# Altium schematic sheet Sheet1.SchDoc(Sheet1)
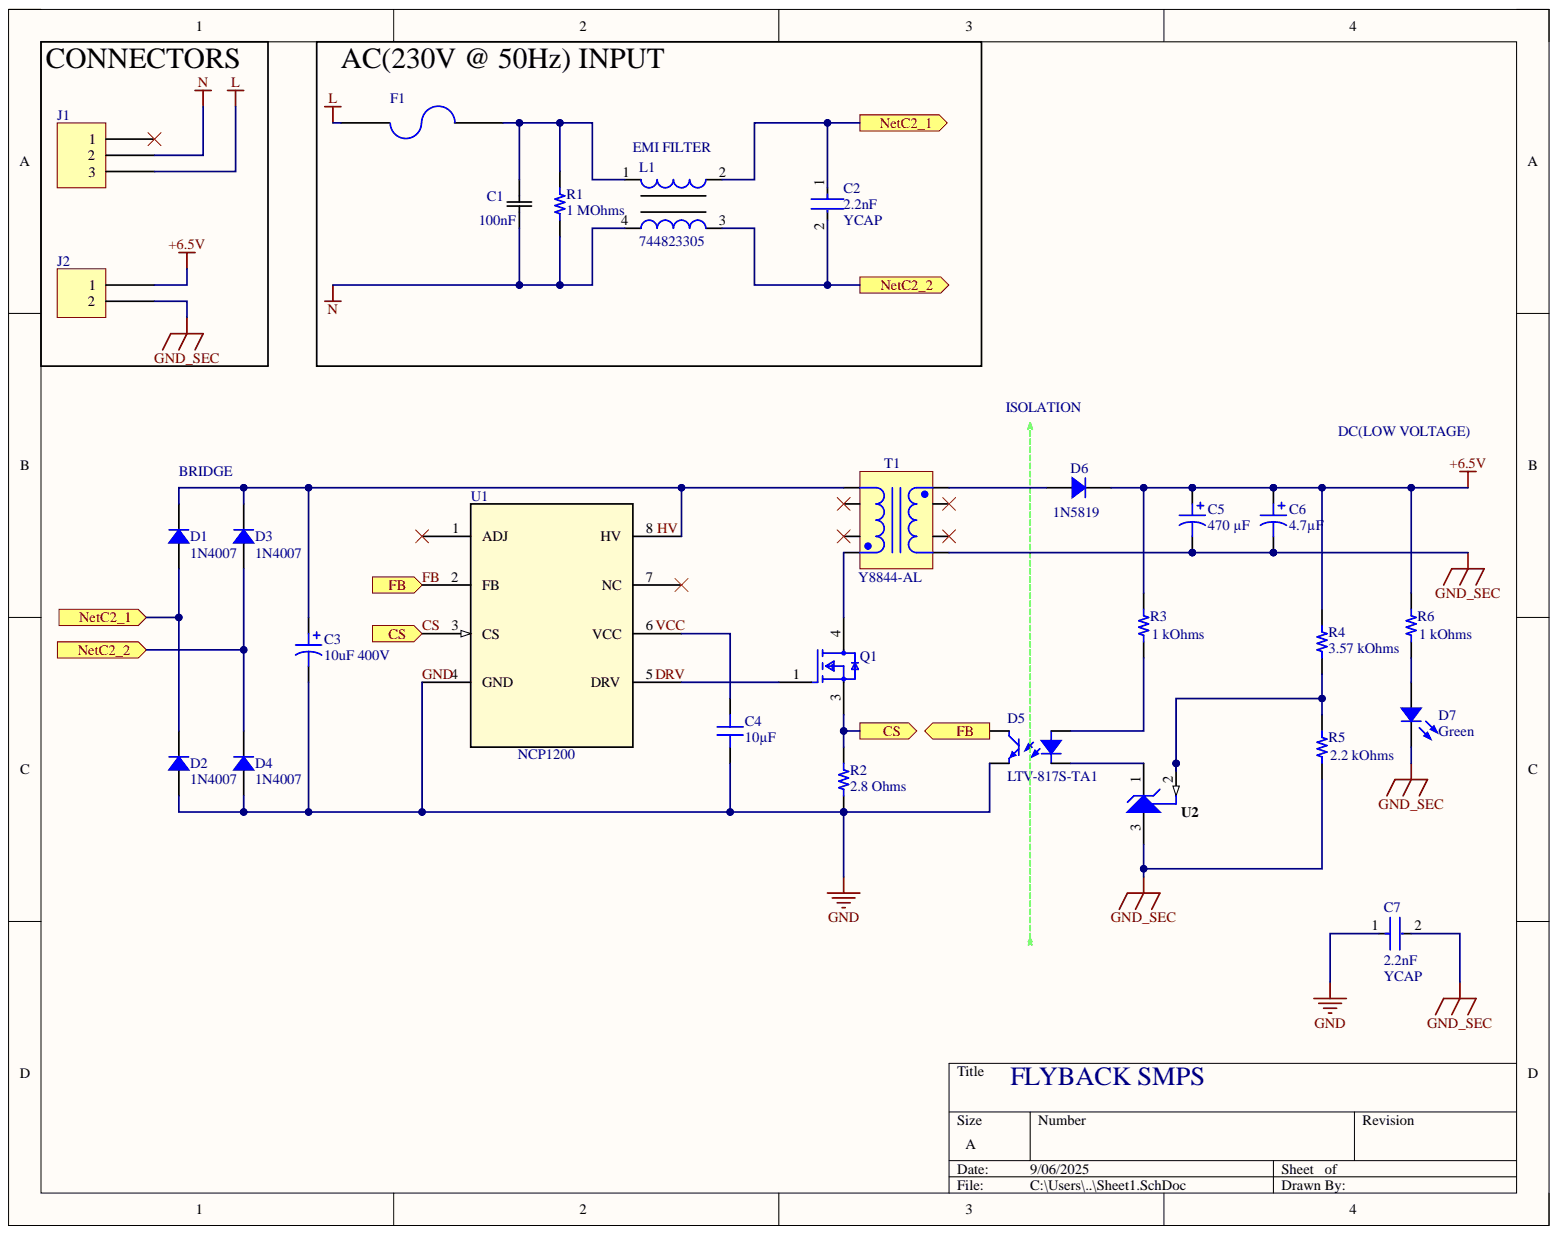
[diagram p0: Sheet1.SchDoc]

## Parts
C1  100nF  [CAP_B32652A--15--8.5x14.5x18_TDK]
C2  YCAP  value 2.2nF  [Vishay_0_MFG]
C3  10uF 400V  [CAP TH ALUM ELEC D10.00mm S5.00mm H17.50mm]
C4  10µF  [CAP 1206_3216 - 1.8MM]
C5  470 µF  [CAP AL TH D8 L3.5 H12.5]
C6  4.7µF  [CAP TH ALUM ELEC D4.00mm S1.50mm H6.00mm]
C7  YCAP  value 2.2nF  [Vishay_0_MFG]
D1  1N4007  [SOD123F]
D2  1N4007  [SOD123F]
D3  1N4007  [SOD123F]
D4  1N4007  [SOD123F]
D5  LTV-817S-TA1  [LITEON LTV-817]
D6  1N5819  [SOD-123]
D7  Green  [LED 0603_1608 GREEN]
F1  0679H2000-05  [FUSE 2410_6125 2]  (value not drawn)
J1  Part Number  [TE 282834-3]  (value not drawn)
J2  282837-2  [TE 282837-2]  (value not drawn)
L1  744823305  [WE-CMB-M]
Q1  STD1NK60T4  [STMicroelectronics_DPAK_TO-252_-0068772_MFG]  (value not drawn)
R1  1 MOhms  [RES 1210_3225]
R2  2.8 Ohms  [RES 1206_3216]
R3  1 kOhms  [RES 0402_1005]
R4  value 3.57 kOhms  [YAGEO RES 1206_3216]  (hidden comment: 220 Ohms)
R5  2.2 kOhms  [RES 0402_1005]
R6  1 kOhms  [RES 0402_1005]
T1  Y8844-AL  [COIL-Y8844-AL-8_V]
U1  NCP1200  [SOIC8_ONS]
U2  TL431AQDBZRQ1  [DBZ0003A_L]  (value not drawn)

## Ports
CS, FB, L filtered, N filtered

## Nets
+6.5V: C5.1 C6.1 D6.2 J2.1 R3.2 R4.2 R6.2
GND_SEC: C5.2 C6.2 C7.2 D7.2 J2.2 R5.1 T1.1 U2.3
L: F1.1 J1.3
N: C1.1 J1.2 L1.4 R1.1
CS: Q1.3 R2.2 U1.3(CS) ; port CS
FB: D5.4 U1.2(FB) ; port FB
GND: C3.2 C4.2 C7.1 D2.1 D4.1 D5.3 R2.1 U1.4(GND)
NetC1_2: C1.2 F1.2 L1.1 R1.2
NetC2_1: C2.1 D1.1 D2.2 L1.2 ; port L filtered
NetC2_2: C2.2 D3.1 D4.2 L1.3 ; port N filtered
NetC3_1: C3.1 D1.2 D3.2 T1.8 U1.8(HV)
NetC4_1: C4.1 U1.6(VCC)
NetD5_1: D5.1 R3.1
NetD5_2: D5.2 U2.1
NetD6_1: D6.1 T1.4
NetD7_1: D7.1 R6.1
NetQ1_1: Q1.1 U1.5(DRV)
NetQ1_4: Q1.4 T1.5
NetR4_1: R4.1 R5.2 U2.2
DRV:
HV:
VCC:

Not connected: J1 1; T1 2 3 6 7; U1 1(ADJ) 7(NC)

## Library data of the parts
C1 = B32652A1103J000: No Description Available; Manufacturer_Name: TDK; Component Kind: Standard; Library Name: transformer.SchLib; Manufacturer_Part_Number: B32652A1103J000; Copyright: Copyright (C) 2025 Ultra Librarian. All rights reserved.
C2 C7 = CMP-001-00084-1: 2200 pF ±20% 500VAC Ceramic Capacitor Y5V (F) Radial, Disc; Manufacturer: Vishay; Part Number: VY1222M37Y5VQ63V0; Symbol Hash: 02cd4be3c70576c341d710602a673aaf100b1e689dd796f6d4934cc5521dd216; Vac X Rating: X1; Vac Y Rating: Y1; Verification Version: 0.0.0.3; Voltage Ac Rating X: 760V; Voltage Ac Rating Y: 500V; Voltage Rating: 1.5kV; Automotive: No; Case/Package: 0; Component Kind: Standard; ComponentLink1Description: Upverter; ComponentLink1URL: https://upverter.com/part/id/97ca20b8586b3509/; ComponentLink2Description: Datasheet; ComponentLink2URL: https://www.vishay.com/docs/28537/vy1series.pdf; Datasheet Path: datasheets/Cap_Vishay_VY1222M37Y5VQ63V0_eec.pdf; Digikey Description: CAP CER 2200PF 500VAC Y5V RADIAL; Digikey Part Number: BC3303-ND; Height: 24.5mm; helpURL: https://upverter.com/part/id/97ca20b8586b3509/; Lead Free: Yes; Material: Ceramic; Mouser Description: VY1 Ceramic Capacitor 2 2NF 20% Y5V; Mouser Part Number: 72-VY1222M37Y5VQ63V0; RoHS: TRUE; Temperature: -40°C to +125°C; Temperature Characteristic: Y5V; Temperature Range High: 125°C; Temperature Range Low: -40°C; Tolerance: 20%; Library Name: Tiberiu's Altium Workspace
C3 = 860241375002: CAP 10 UF 20% 400 V; manufacturer: Würth Elektronik; Capacitance: 10µF; Database Table Name: Capacitors - Aluminum - Electrolytic TH; Lifetime @ Temp.: 10000 Hrs @ 105°C; Polarization: Polar; Ripple Current @ High Frequency: 274mA @ 100kHz; Ripple Current @ Low Frequency: 137mA @ 120Hz; value: 10µF; Applications: General Purpose; Component Kind: Standard; componentlink1description: Datasheet; componentlink1url: https://katalog.we-online.de/pbs/datasheet/860241375002.pdf; componentlink2description: DigiKey Link; componentlink2url: http://digikey.com/product-detail/en/w-rth-elektronik/860241375002/732-9301-1-ND/5729239; Device Package: Radial, Can; Height - Seated (Max): 0.689" (17.50mm); lastupdated: 9/2/2020 1:31:25 AM; Lead Spacing: 0.197" (5.00mm); Library Name: Celestial Altium Library - altium_library.DbLib; Lifecycle Status: Active; Minimum Order: 1; Mounting Type: Through Hole; Operating Temperature: -25°C ~ 105°C; Package / Case: Radial, Can; packaging: TapeAndBox; Part Number: 860241375002; Part Status: Active; partid: 12069; price: 0.48; series: WCAP-AT1H; Size / Dimension: 0.394" Dia (10.00mm); Supplier 1: DigiKey; Supplier Part Number 1: 732-9301-1-ND; Tolerance: ±20%; Voltage - Rated: 400V
C4 = 12065D106KAT2A: CAP CER 10UF 50V X5R 1206; manufacturer: AVX Corporation; Database Table Name: Capacitors - Ceramic - 1206; Tolerance: ±10%; Applications: General Purpose; Capacitance: 10µF; Component Kind: Standard; componentlink1description: Datasheet; componentlink1url: http://datasheets.avx.com/cx5r.pdf; componentlink2description: DigiKey Link; componentlink2url: http://digikey.com/product-detail/en/avx-corporation/12065D106KAT2A/478-9979-1-ND/6149060; Device Package: 1206 (3216 Metric); lastupdated: 9/2/2020 1:05:35 AM; Library Name: Celestial Altium Library - altium_library.DbLib; Lifecycle Status: Active; Minimum Order: 1; Mounting Type: Surface Mount, MLCC; Operating Temperature: -55°C ~ 85°C; Package / Case: 1206 (3216 Metric); packaging: TapeAndReel; Part Number: 12065D106KAT2A; Part Status: Active; partid: 21345; price: 0.16; series: -; Size / Dimension: 0.126" L x 0.063" W (3.20mm x 1.60mm); Supplier 1: DigiKey; Supplier Part Number 1: 478-9979-1-ND; Temperature Coefficient: X5R; Thickness (Max): 0.071" (1.80mm); value: 10µF; Voltage - Rated: 50V
C5 = UKW1C471MPD1TD: CAP ALUM 470UF 20% 16V RADIAL; manufacturer: Nichicon; Database Table Name: Capacitors - Aluminum - Electrolytic TH; Lifetime @ Temp.: 2000 Hrs @ 85°C; Polarization: Polar; Ripple Current @ Low Frequency: 420 mA @ 120 Hz; Applications: Audio; Capacitance: 470 µF; Component Kind: Standard; componentlink1description: Datasheet; componentlink1url: http://nichicon-us.com/english/products/pdfs/e-ukw.pdf; componentlink2description: DigiKey Link; componentlink2url: https://www.digikey.com/en/products/detail/nichicon/UKW1C471MPD1TD/4317336; Device Package: Radial, Can; Height - Seated (Max): 0.492" (12.50mm); lastupdated: 6/3/2024 8:06:12 PM; Lead Spacing: 0.138" (3.50mm); Library Name: Celestial Altium Library - altium_library.DbLib; Lifecycle Status: Active; Minimum Order: 1; Mounting Type: Through Hole; Operating Temperature: -40°C ~ 85°C; Package / Case: Radial, Can; packaging: TapeAndBox; Part Number: UKW1C471MPD1TD; Part Status: Active; partid: 7216; price: 0.23; series: UKW; Size / Dimension: 0.315" Dia (8.00mm); Supplier 1: DigiKey; Supplier Part Number 1: 493-10758-1-ND; Tolerance: ±20%; value: 470 µF; Voltage - Rated: 16 V
C6 = UMP1C4R7MDD1TP: CAP ALUM 4.7UF 20% 16V RADIAL; manufacturer: Nichicon; Database Table Name: Capacitors - Aluminum - Electrolytic TH; Lifetime @ Temp.: 2000 Hrs @ 85°C; Polarization: Bi-Polar; Ripple Current @ High Frequency: 18mA @ 10kHz; Ripple Current @ Low Frequency: 12mA @ 120Hz; Applications: General Purpose; Capacitance: 4.7µF; Component Kind: Standard; componentlink1description: Datasheet; componentlink1url: http://nichicon-us.com/english/products/pdfs/e-ump.pdf; componentlink2description: DigiKey Link; componentlink2url: http://digikey.com/product-detail/en/nichicon/UMP1C4R7MDD1TP/493-10248-1-ND/4312507; Device Package: Radial, Can; Height - Seated (Max): 0.236" (6.00mm); lastupdated: 9/6/2020 1:48:30 AM; Lead Spacing: 0.059" (1.50mm); Library Name: Celestial Altium Library - altium_library.DbLib; Lifecycle Status: Active; Minimum Order: 1; Mounting Type: Through Hole; Operating Temperature: -40°C ~ 85°C; Package / Case: Radial, Can; packaging: TapeAndBox; Part Number: UMP1C4R7MDD1TP; Part Status: Active; partid: 23164; price: 0.11; series: UMP; Size / Dimension: 0.157" Dia (4.00mm); Supplier 1: DigiKey; Supplier Part Number 1: 493-10248-1-ND; Tolerance: ±20%; value: 4.7µF; Voltage - Rated: 16V
D1-D4 = 1N4007FLTR: STANDARD RECTIFIER 1000V SOD-123; Manufacturer: SMC Diode Solutions; Capacitance @ Vr, F: 15pF @ 4V, 1MHz; Current - Average Rectified (Io): 1A; Current - Reverse Leakage @ Vr: 5µA @ 1000V; Database Table Name: Diodes - Rectifiers - Single; Speed: Standard Recovery >500ns, > 200mA (Io); Voltage - Forward (Vf) (Max) @ If: 1.1V @ 1A; Component Kind: Standard; ComponentLink1Description: Datasheet; ComponentLink1URL: http://www.smc-diodes.com/propdf/1N4001FL-1N4007FL%20N1646%20REV.A.pdf; ComponentLink2Description: DigiKey Link; ComponentLink2URL: http://digikey.com/product-detail/en/smc-diode-solutions/1N4007FLTR/1655-1N4007FLCT-ND/11657827; Diode Type: Standard; LastUpdated: 8/30/2020 8:59:55 PM; Library Name: Celestial Altium Library - altium_library.DbLib; Lifecycle Status: Active; Minimum Order: 1; Mounting Type: Surface Mount; Package / Case: SOD-123F; Packaging: TapeAndReel; Part Number: 1N4007FLTR; PartId: 3314; Price: 0.01; Supplier 1: DigiKey; Supplier Device Package: SOD-123FL; Supplier Part Number 1: 1655-1N4007FLCT-ND; Voltage - DC Reverse (Vr) (Max): 1000V
D5 = LTV-817S-TA1: OPTOISOLATR 5KV TRANSISTOR 4-SMD; Manufacturer: Lite-On Inc.; Current - DC Forward (If) (Max): 50mA; Current Transfer Ratio (Max): 600% @ 5mA; Current Transfer Ratio (Min): 50% @ 5mA; Database Table Name: Optoisolators - Transistor Photovoltaic; Input Type: DC; Number of Channels: 1; Rise / Fall Time (Typ): 4µs, 3µs; Vce Saturation (Max): 200mV; Voltage - Forward (Vf) (Typ): 1.2V; Voltage - Isolation: 5000Vrms; Voltage - Output (Max): 35V; Component Kind: Standard; ComponentLink1Description: Datasheet; ComponentLink1URL: http://optoelectronics.liteon.com/upload/download/DS-70-96-0016/LTV-8X7%20series%20201610%20.pdf; ComponentLink2Description: DigiKey Link; ComponentLink2URL: http://digikey.com/product-detail/en/lite-on-inc/LTV-817S-TA1/160-1893-1-ND/3306757; Current - Output / Channel: 50mA; Device Package: 4-SMD, Gull Wing; LastUpdated: 1/12/2020 9:58:48 PM; Library Name: Celestial Altium Library - altium_library.DbLib; Lifecycle Status: Active; Minimum Order: 1; Mounting Type: Surface Mount; Operating Temperature: -30°C ~ 110°C; Output Type: Transistor; Package / Case: 4-SMD, Gull Wing; Packaging: TapeAndReel; Part Number: LTV-817S-TA1; Part Status: Active; Price: 0.12; Series: -; Supplier 1: DigiKey; Supplier Device Package: 4-SMD; Supplier Part Number 1: 160-1893-1-ND
D6 = 1N5819HW-7-F: DIODE SCHOTTKY 40V 1A SOD123; Manufacturer: Diodes Incorporated; Capacitance @ Vr, F: 60pF @ 4V, 1MHz; Current - Reverse Leakage @ Vr: 1 mA @ 40 V; Database Table Name: Diodes - Rectifiers - Single; Speed: Fast Recovery =< 500ns, > 200mA (Io); Voltage - Forward (Vf) (Max) @ If: 450 mV @ 1 A; Component Kind: Standard; ComponentLink1Description: Datasheet; ComponentLink1URL: https://www.diodes.com/assets/Datasheets/ds30217.pdf; ComponentLink2Description: DigiKey Link; ComponentLink2URL: https://www.digikey.com/en/products/detail/diodes-incorporated/1N5819HW-7-F/814970; Current - Average Rectified (Io): 1A; Diode Type: Schottky; LastUpdated: 6/3/2024 7:54:46 PM; Library Name: Celestial Altium Library - altium_library.DbLib; Lifecycle Status: Active; Minimum Order: 1; Mounting Type: Surface Mount; Operating Temperature - Junction: -65°C ~ 125°C; Package / Case: SOD-123; Packaging: TapeAndReel; Part Number: 1N5819HW-7-F; Part Status: Active; PartId: 2212; Price: 0.05; Series: -; Supplier 1: DigiKey; Supplier Device Package: SOD-123; Supplier Part Number 1: 1N5819HW-FDICT-ND; Voltage - DC Reverse (Vr) (Max): 40 V
D7 = LT Q99G.01-R2T1-25-1: NEXT GENERATION OF CHIP LED 0603; Manufacturer: ams-OSRAM USA Inc.; Current - Test: 5mA; Database Table Name: LED - Indication; Lens Color: Colorless; Lens Size: 1.10mm x 0.80mm; Lens Style: Rectangle with Flat Top; Lens Transparency: Clear; Millicandela Rating: 247.5mcd; Viewing Angle: 140°; Voltage - Forward (Vf) (Typ): 2.9V; Wavelength - Dominant: 530nm; Wavelength - Peak: 518nm; Color: Green; Component Kind: Standard; ComponentLink2Description: DigiKey Link; ComponentLink2URL: https://www.digikey.com/en/products/detail/ams-osram-usa-inc/LT-Q99G-01-R2T1-25-1/21556499; Device Package: 0603 (1608 Metric); Height (Max): 0.75mm; LastUpdated: 8/25/2025 8:35:06 PM; Library Name: Celestial Altium Library - altium_library.DbLib; Lifecycle Status: Active; Minimum Order: 1; Mounting Type: Surface Mount; Package / Case: 0603 (1608 Metric); Packaging: TapeAndReel; Part Number: LT Q99G.01-R2T1-25-1; PartId: 1419; Price: 0.03; Series: Chip LED 0603; Size / Dimension: 1.60mm L x 0.80mm W; Supplier 1: DigiKey; Supplier Device Package: 0603; Supplier Part Number 1: 475-LTQ99G.01-R2T1-25-1CT-ND; Value: Green
F1 = 0679H2000-05: FUSE BOARD MNT 2A 350VAC 72VDC; Manufacturer: Bel Fuse Inc.; Approval Agency: cURus; Breaking Capacity @ Rated Voltage: 50A AC, 100A DC; Database Table Name: Fuses - Fuse; Fuse Type: Board Mount (Cartridge Style Excluded); Melting I²t: 0.68; Response Time: Fast Blow; Voltage Rating - AC: 350V; Voltage Rating - DC: 72V; Approvals: cURus; Component Kind: Standard; ComponentLink1Description: Datasheet; ComponentLink1URL: https://www.belfuse.com/resources/CircuitProtection/datasheets/0679H%20Jan%202017.pdf; ComponentLink2Description: DigiKey Link; ComponentLink2URL: http://digikey.com/product-detail/en/bel-fuse-inc/0679H2000-05/507-2049-1-ND/6139813; Current Rating (Amps): 2A; LastUpdated: 6/3/2024 8:26:42 PM; Library Name: Celestial Altium Library - altium_library.DbLib; Lifecycle Status: Active; Minimum Order: 1; Mounting Type: Surface Mount; Operating Temperature: -55°C ~ 125°C; Package / Case: 2-SMD, Square End Block; Packaging: TapeAndReel; Part Number: 0679H2000-05; Part Status: Active; Price: 0.14; Series: 0679H; Size / Dimension: 0.240" L x 0.100" W x 0.110" H (6.10mm x 2.54mm x 2.79mm); Supplier 1: DigiKey; Supplier Part Number 1: 507-2049-1-ND
J1 = 282834-3: TERM BLK 3P SIDE ENT 2.54MM PCB; Manufacturer: TE Connectivity AMP Connectors; Current: 10A; Database Table Name: Connectors - Terminal Blocks - Wire to Board; Mating Orientation: Horizontal with Board; Number of Levels: 1; Pitch: 0.100" (2.54mm); Positions Per Level: 3; Voltage: 150V; Wire Gauge: 16-30 AWG; Wire Termination: Screw - Rising Cage Clamp; Color: Green; Component Kind: Standard; ComponentLink1Description: Datasheet; ComponentLink1URL: https://www.te.com/commerce/DocumentDelivery/DDEController?Action=srchrtrv&DocNm=282834&DocType=Customer+Drawing&DocLang=English; ComponentLink2Description: DigiKey Link; ComponentLink2URL: http://digikey.com/product-detail/en/te-connectivity-amp-connectors/282834-3/A98334-ND/1153264; LastUpdated: 1/15/2020 4:15:50 AM; Library Name: Celestial Altium Library - altium_library.DbLib; Lifecycle Status: Active; Minimum Order: 1; Mounting Type: Through Hole; Packaging: Bulk; Part Number: 282834-3; Part Status: Active; Price: 2.01; Series: Buchanan; Supplier 1: DigiKey; Supplier Part Number 1: A98334-ND
J2 = 282837-2: TERM BLK 2P SIDE ENT 5.08MM PCB; Manufacturer: TE Connectivity AMP Connectors; Current: 13.5 A; Database Table Name: Connectors - Terminal Blocks - Wire to Board; Mating Orientation: Horizontal with Board; Number of Levels: 1; Pitch: 0.200" (5.08mm); Positions Per Level: 2; Voltage: 300 V; Wire Gauge: 16-28 AWG; Wire Termination: Screw - Rising Cage Clamp; Color: Green; Component Kind: Standard; ComponentLink1Description: Datasheet; ComponentLink1URL: https://www.te.com/commerce/DocumentDelivery/DDEController?Action=srchrtrv&DocNm=282837&DocType=Customer+Drawing&DocLang=English; ComponentLink2Description: DigiKey Link; ComponentLink2URL: https://www.digikey.com/en/products/detail/te-connectivity-amp-connectors/282837-2/2187973; Features: Interlocking (Side); LastUpdated: 6/21/2022 3:00:23 PM; Library Name: Celestial Altium Library - altium_library.DbLib; Lifecycle Status: Active; Minimum Order: 1; Mounting Type: Through Hole; Packaging: Tray; Part Number: 282837-2; Part Status: Active; Price: 0.31; Series: Buchanan; Supplier 1: DigiKey; Supplier Part Number 1: A113320-ND
L1 = 744823305: THT Common Mode Power Line Choke WE-CMB, L = 5.00 mH; Inductance: 5.00 mH; Component Kind: Standard; ComponentLink1Description: Manufacturer Link; ComponentLink1URL: http://www.we-online.com; ComponentLink2Description: Datasheet; ComponentLink2URL: http://www.we-online.de/katalog/media/pdf/744823305.pdf; DatasheetVersion: ver 7, Nov-2006; Library Name: transformer.SchLib; PackageDescription: THT Inductor, 4-Leads, Body 25.0 x 17.0 mm; PackageReference: WE-CMB-M; PackageVersion: 2006; PartNumber: 744823305
Q1 = CMP-007-000000-1: N-Channel 600V 1A (Tc) 30W (Tc) Surface Mount DPAK; Manufacturer: STMicroelectronics; Depletion Mode: False; Drain To Source Resistance: 7.3Ω; Gate Charge At Vgs: 7nC @ 10V; Gate To Source Voltage: 30V; Input Capacitace At Vds: 156pF @ 25V; Max Forward Diode Voltage: 1.6V; Max Junction Temp: +150°C; Number Of Channels: 1; Pulse Drain Current: 4A; Reverse Recovery Charge: 240nC; Reverse Recovery Time: 140ns; Rthja Max: 100°C/W; Standoff Height: 0.03mm; Symbol Hash: 86656c45bbbddc162aead764a772c09aa28df33f5023c6f9e1f954939099aa0e; Threshold Vgs: 3V; Threshold Vgs Max: 3.7V; Threshold Vgs Min: 2.25V; Turn Off Delay Time: 19ns; Turn On Delay Time: 6.5ns; Verification Version: 0.0.0.3; Automotive: No; Component Kind: Standard; ComponentLink1Description: Upverter; ComponentLink1URL: https://upverter.com/part/id/826df9c6efb694a8/; ComponentLink2Description: Datasheet; ComponentLink2URL: https://www.st.com/resource/en/datasheet/std1nk60t4.pdf; Continuous Drain Current: 1A; Datasheet Path: datasheets/Trans_STMicroelectronics_STD1NK60T4_eec.pdf; Digikey Description: MOSFET N-CH 600V 1A DPAK; Digikey Part Number: 497-2483-1-ND; Drain To Source Breakdown Voltage: 600V; Drain To Source Voltage: 600V; Height: 2.63mm; helpURL: https://upverter.com/part/id/826df9c6efb694a8/; Lead Free: Yes; Mouser Description: MOSFET N-Ch 600 Volt 1.0Amp Zener SuperMESH; Mouser Part Number: 511-STD1NK60; Number Of N Channels: 1; Package: DPAK(TO-252)-0068772; Part Number: STD1NK60T4; Power Dissipation: 30W; RoHS: TRUE; Technology: MOSFET; Temperature: -55°C to +150°C; Temperature Range High: 150°C; Temperature Range Low: -55°C; Library Name: Tiberiu's Altium Workspace
R1 = CRGP1210F1M0: RES 1M OHM 1% 3/4W 1210; Manufacturer: TE Connectivity Passive Product; Database Table Name: Resistors - Surface Mount - 1210; Power (Watts): 0.75W, 3/4W; Temperature Coefficient: ±100ppm/°C; Component Kind: Standard; ComponentLink1Description: Datasheet; ComponentLink1URL: https://www.te.com/commerce/DocumentDelivery/DDEController?Action=srchrtrv&DocNm=9-1773463-9&DocType=DS&DocLang=English; ComponentLink2Description: DigiKey Link; ComponentLink2URL: https://www.digikey.com/en/products/detail/te-connectivity-passive-product/CRGP1210F1M0/8577237; Composition: Thick Film; Device Package: 1210 (3225 Metric); Features: Pulse Withstanding; Height - Seated (Max): 0.026" (0.65mm); LastUpdated: 6/3/2024 8:19:02 PM; Library Name: Celestial Altium Library - altium_library.DbLib; Lifecycle Status: Active; Minimum Order: 1; Number of Terminations: 2; Operating Temperature: -55°C ~ 155°C; Package / Case: 1210 (3225 Metric); Packaging: TapeAndReel; Part Number: CRGP1210F1M0; Part Status: Active; Price: 0.05; Resistance: 1 MOhms; Series: CRGP; Size / Dimension: 0.122" L x 0.102" W (3.10mm x 2.60mm); Supplier 1: DigiKey; Supplier Device Package: 1210; Supplier Part Number 1: A130635CT-ND; Tolerance: ±1%; Value: 1 MOhms
R2 = CPF1206B2R8E1: CPF 1206 2R8 0.1% 25PPM 1K RL; Manufacturer: TE Connectivity Passive Product; Database Table Name: Resistors - Surface Mount - 1206; Power (Watts): 0.125W, 1/8W; Temperature Coefficient: ±25ppm/°C; Component Kind: Standard; ComponentLink1Description: Datasheet; ComponentLink1URL: https://www.te.com/commerce/DocumentDelivery/DDEController?Action=srchrtrv&DocNm=1773200&DocType=DS&DocLang=English; ComponentLink2Description: DigiKey Link; ComponentLink2URL: https://www.digikey.com/en/products/detail/te-connectivity-passive-product/CPF1206B2R8E1/14006927; Composition: Thin Film; Device Package: 1206 (3216 Metric); Height - Seated (Max): 0.026" (0.65mm); LastUpdated: 2/9/2024 8:53:26 PM; Library Name: Celestial Altium Library - altium_library.DbLib; Lifecycle Status: Active; Minimum Order: 1; Number of Terminations: 2; Operating Temperature: -55°C ~ 155°C; Package / Case: 1206 (3216 Metric); Packaging: TapeAndReel; Part Number: CPF1206B2R8E1; Part Status: Active; PartId: 58938; Price: 0.16; Resistance: 2.8 Ohms; Series: CPF; Size / Dimension: 0.120" L x 0.061" W (3.05mm x 1.55mm); Supplier 1: DigiKey; Supplier Device Package: 1206; Supplier Part Number 1: 1712-CPF1206B2R8E1CT-ND; Tolerance: ±0.1%; Value: 2.8 Ohms
R3 R6 = CPF0402B1K0E: RES SMD 1K OHM 0.1% 1/16W 0402; Manufacturer: TE Connectivity Passive Product; Database Table Name: Resistors - Surface Mount - 0402; Power (Watts): 0.063W, 1/16W; Temperature Coefficient: ±25ppm/°C; Component Kind: Standard; ComponentLink1Description: Datasheet; ComponentLink1URL: https://www.te.com/commerce/DocumentDelivery/DDEController?Action=srchrtrv&DocNm=1773200&DocType=DS&DocLang=English; ComponentLink2Description: DigiKey Link; ComponentLink2URL: https://www.digikey.com/en/products/detail/te-connectivity-passive-product/CPF0402B1K0E/4966468; Composition: Thin Film; Device Package: 0402 (1005 Metric); Height - Seated (Max): 0.014" (0.35mm); LastUpdated: 2/9/2024 8:30:24 PM; Library Name: Celestial Altium Library - altium_library.DbLib; Lifecycle Status: Active; Minimum Order: 1; Number of Terminations: 2; Operating Temperature: -55°C ~ 155°C; Package / Case: 0402 (1005 Metric); Packaging: TapeAndReel; Part Number: CPF0402B1K0E; Part Status: Active; PartId: 2470; Price: 0.10; Resistance: 1 kOhms; Series: CPF, Neohm; Size / Dimension: 0.039" L x 0.020" W (1.00mm x 0.50mm); Supplier 1: DigiKey; Supplier Device Package: 0402; Supplier Part Number 1: A119928CT-ND; Tolerance: ±0.1%; Value: 1 kOhms
R4 = RC0402FR-073K57L: RES 3.57K OHM 1% 1/16W 0402; Manufacturer: Yageo; Database Table Name: Resistors - Surface Mount - 0402; Power (Watts): 0.063W, 1/16W; Resistance: 3.57 kOhms; Temperature Coefficient: ±100ppm/°C; Value: 3.57 kOhms; Component Kind: Standard; ComponentLink1Description: Datasheet; ComponentLink1URL: https://www.yageo.com/upload/media/product/productsearch/datasheet/rchip/PYu-RC_Group_51_RoHS_L_10.pdf; ComponentLink2Description: DigiKey Link; ComponentLink2URL: https://www.digikey.com/en/products/detail/yageo/RC0402FR-073K57L/5281010; Composition: Thick Film; Device Package: 0402 (1005 Metric); Features: Moisture Resistant; Height - Seated (Max): 0.016" (0.40mm); LastUpdated: 8/31/2025 3:04:18 PM; Library Name: Celestial Altium Library - altium_library.DbLib; Lifecycle Status: Active; Minimum Order: 1; Number of Terminations: 2; Operating Temperature: -55°C ~ 155°C; Package / Case: 0402 (1005 Metric); Packaging: CutTape; Part Number: RC0402FR-073K57L; Part Status: Active; PartId: 2603; Price: 0.01; Series: RC_L; Size / Dimension: 0.039" L x 0.020" W (1.00mm x 0.50mm); Supplier 1: DigiKey; Supplier Device Package: 0402; Supplier Part Number 1: YAG3150CT-ND; Tolerance: ±1%
R5 = RR0510P-222-D: RES SMD 2.2K OHM 0.5% 1/16W 0402; Manufacturer: Susumu; Database Table Name: Resistors - Surface Mount - 0402; Power (Watts): 0.063W, 1/16W; Temperature Coefficient: ±25ppm/°C; Component Kind: Standard; ComponentLink1Description: Datasheet; ComponentLink1URL: http://www.susumu.co.jp/common/pdf/n_catalog_partition05_en.pdf; ComponentLink2Description: DigiKey Link; ComponentLink2URL: https://www.digikey.com/en/products/detail/susumu/RR0510P-222-D/432405; Composition: Thin Film; Device Package: 0402 (1005 Metric); Height - Seated (Max): 0.016" (0.40mm); LastUpdated: 2/9/2024 8:30:21 PM; Library Name: Celestial Altium Library - altium_library.DbLib; Lifecycle Status: Active; Minimum Order: 1; Number of Terminations: 2; Operating Temperature: -55°C ~ 125°C; Package / Case: 0402 (1005 Metric); Packaging: TapeAndReel; Part Number: RR0510P-222-D; Part Status: Active; PartId: 3229; Price: 0.01; Resistance: 2.2 kOhms; Series: RR; Size / Dimension: 0.039" L x 0.020" W (1.00mm x 0.50mm); Supplier 1: DigiKey; Supplier Part Number 1: RR05P2.2KDCT-ND; Tolerance: ±0.5%; Value: 2.2 kOhms
T1 = Y8844-AL: Flyback Transformer, 3.5 W, -40 to 85 degC, 18.3 x 20.1 x 14 mm Package; Manufacturer: Coilcraft; DCR max (Ohms): 4.25 (8-5) 0.07 (4-1); Inductance +/-10% (mH): 2.9; Isolation (Vrms): 1500; Leakage inductance max (uH): 26; Output: 6.5 V, 0.54 A; Application: 3.5 W; Component Kind: Standard; ComponentLink1Description: Manufacturer URL; ComponentLink1URL: http://www.coilcraft.com/; ComponentLink2Description: Datasheet; ComponentLink2URL: http://www.coilcraft.com/pdf_viewer/showpdf.cfm?f=pdf_store:y8844.pdf; ComponentLink3Description: Package Specification; ComponentLink3URL: http://www.coilcraft.com/pdf_viewer/showpdf.cfm?f=pdf_store:y8844.pdf; DatasheetVersion: 31/01/2005; Library Name: transformer.SchLib; Mounting Technology: Through Hole; Operating Temperature Range: -40 to 85 degC; PackageDescription: 8-Pin Through Hole Package, Body 18.3 x 20.1 mm; PackageReference: Y8844-AL-8; PartNumber: Y8844-AL
U1 = NCP1200AD100R2: No Description Available; Manufacturer_Name: onsemi; Component Kind: Standard; Library Name: transformer.SchLib; Manufacturer_Part_Number: NCP1200AD100R2; RefDes: RefDes; Type: Type; Copyright: Copyright (C) 2025 Ultra Librarian. All rights reserved.
U2 = TL431AQDBZRQ1: Automotive Catalog Adjustable Precision Shunt Regulator, 34 ppm / degC, 100 mA, -40 to 125 degC, 3-pin SOT-23 (DBZ), Green (RoHS & no Sb/Br); Manufacturer: Texas Instruments; Initial Accuracy @ 25/deg C(%): 1; Iout/Iz(Max)(mA): 100; Min Iz for Regulation(uA): 600; SubFamily: Shunt Voltage Reference; Temp Coeff(Typ)(ppm/ degree C): 34; VO Adj(Max)(V): 36; VO Adj(Min)(V): 2.495; Code_JEDEC: TO-236; Component Kind: Standard; ComponentLink1Description: Manufacturer URL; ComponentLink1URL: http://www.ti.com/; ComponentLink2Description: Datasheet; ComponentLink2URL: http://www.ti.com/general/docs/lit/getliterature.tsp?genericPartNumber=TL431A-Q1&fileType=pdf; ComponentLink3Description: Package Specification; ComponentLink3URL: http://www.ti.com/litv/pdf/mpds108a; DatasheetVersion: SGLS302C; Eco-Plan: Green (RoHS & no Sb/Br); Library Name: transformer.SchLib; Mounting Technology: Surface Mount; PackageDescription: 3-Pin Plastic Small Outline, Body 2.92 x 1.3 mm, Pitch 0.95 mm; PackageReference: DBZ0003A; PackageVersion: 4203227/B; PartNumber: TL431AQDBZRQ1; Rating: Automotive
